# Altium schematic sheet RGB.SchDoc
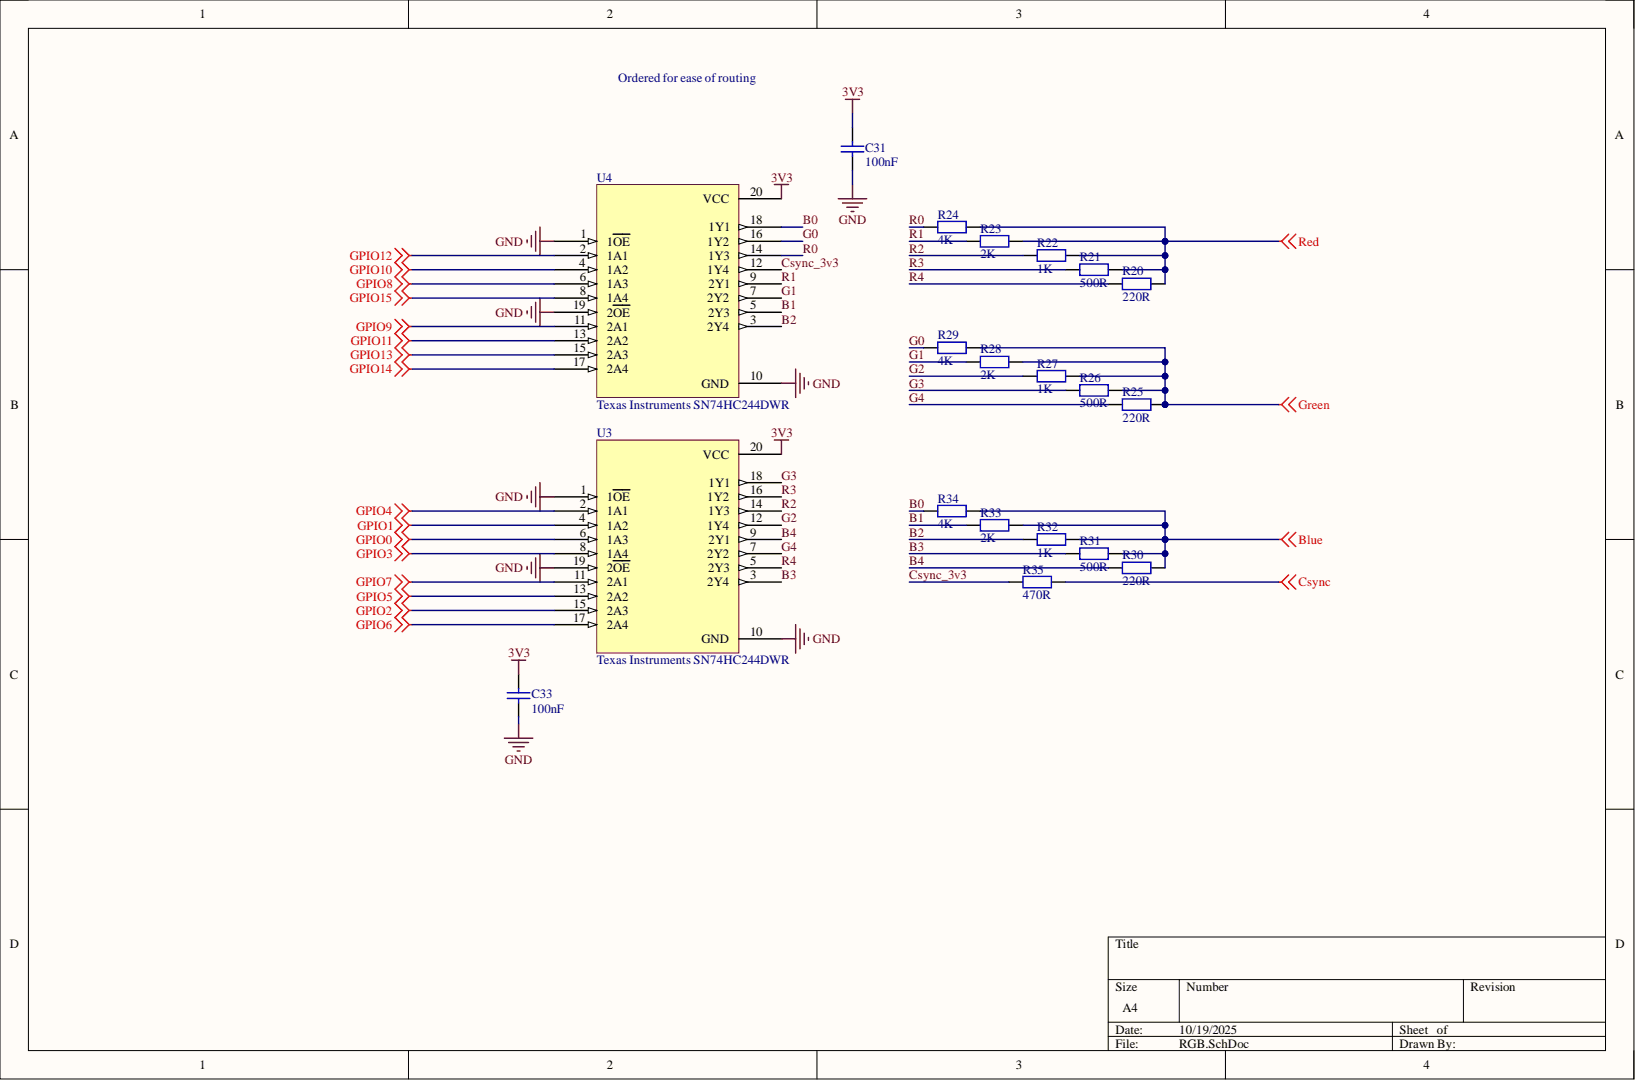
[diagram p0: RGB.SchDoc]

## Parts
C31  100nF  [PCB-god88euyegdvhk3bnqo6-1]
C33  100nF  [PCB-god88euyegdvhk3bnqo6-1]
R20  220R  [PCB-7wrvqjq7seaxrusqyahf-1]
R21  500R  [PCB-7wrvqjq7seaxrusqyahf-1]
R22  1K  [PCB-7wrvqjq7seaxrusqyahf-1]
R23  2K  [PCB-7wrvqjq7seaxrusqyahf-1]
R24  4K  [PCB-7wrvqjq7seaxrusqyahf-1]
R25  220R  [PCB-7wrvqjq7seaxrusqyahf-1]
R26  500R  [PCB-7wrvqjq7seaxrusqyahf-1]
R27  1K  [PCB-7wrvqjq7seaxrusqyahf-1]
R28  2K  [PCB-7wrvqjq7seaxrusqyahf-1]
R29  4K  [PCB-7wrvqjq7seaxrusqyahf-1]
R30  220R  [PCB-7wrvqjq7seaxrusqyahf-1]
R31  500R  [PCB-7wrvqjq7seaxrusqyahf-1]
R32  1K  [PCB-7wrvqjq7seaxrusqyahf-1]
R33  2K  [PCB-7wrvqjq7seaxrusqyahf-1]
R34  4K  [PCB-7wrvqjq7seaxrusqyahf-1]
R35  470R  [PCB-7wrvqjq7seaxrusqyahf-1]
U3  Texas Instruments SN74HC244DWR  Manufacturer Part Number: SN74HC244DWR  [TI-DW20_M]
U4  Texas Instruments SN74HC244DWR  Manufacturer Part Number: SN74HC244DWR  [TI-DW20_M]

## Nets
3V3: C31.2 C33.2 U3.20(VCC) U4.20(VCC)
Blue: R30.1 R31.1 R32.1 R33.1 R34.1
Csync: R35.1
GND: C31.1 C33.1 U3.1(1OE) U3.10(GND) U3.19(2OE) U4.1(1OE) U4.10(GND) U4.19(2OE)
GPIO0: U3.6(1A3)
GPIO1: U3.4(1A2)
GPIO2: U3.15(2A3)
GPIO3: U3.8(1A4)
GPIO4: U3.2(1A1)
GPIO5: U3.13(2A2)
GPIO6: U3.17(2A4)
GPIO7: U3.11(2A1)
GPIO8: U4.6(1A3)
GPIO9: U4.11(2A1)
GPIO10: U4.4(1A2)
GPIO11: U4.13(2A2)
GPIO12: U4.2(1A1)
GPIO13: U4.15(2A3)
GPIO14: U4.17(2A4)
GPIO15: U4.8(1A4)
Green: R25.1 R26.1 R27.1 R28.1 R29.1
Red: R20.1 R21.1 R22.1 R23.1 R24.1
B0: R34.2 U4.18(1Y1)
B1: R33.2 U4.5(2Y3)
B2: R32.2 U4.3(2Y4)
B3: R31.2 U3.3(2Y4)
B4: R30.2 U3.9(2Y1)
Csync_3v3: R35.2 U4.12(1Y4)
G0: R29.2 U4.16(1Y2)
G1: R28.2 U4.7(2Y2)
G2: R27.2 U3.12(1Y4)
G3: R26.2 U3.18(1Y1)
G4: R25.2 U3.7(2Y2)
R0: R24.2 U4.14(1Y3)
R1: R23.2 U4.9(2Y1)
R2: R22.2 U3.14(1Y3)
R3: R21.2 U3.16(1Y2)
R4: R20.2 U3.5(2Y3)

## Library data of the parts
C31 C33 = CMP-ee2a246dec7f31ed-7: Capacitor; Ceramic; Cap 0.1 uF; Tol 10%; Vol-Rtg 25 VDC; SMT; 0805; X7R; Tape & Reel; Component Kind: Standard; Library Name: My Personal Space
R20-R35 = CMP-3bd8f394a633e41f-2: Res Thin Film 2.0 x 1.2 mm 2K Ohm 0.1% 0.1W(1/10W) 10ppm/ C Molded SMD Paper T/R; Manufacturer Part Number: RN73C2A2K0BTD; Case/Package (SI): 2012; ELV Compliant: Compliant; Power Rating: 100 mW; Resistance: 2.00 kΩ; Voltage Rating (DC): 100 V; Case/Package: 0805; Component Kind: Standard; Library Name: My Personal Space; Lifecycle Status: Active; Mounting Style: Surface Mount; Number of Pins: 2; Octopart URL: http://octopart.com/rn73c2a2k0btdf-te+connectivity-21411981; OctopartID: 3bd8f394a633e41f; Packaging: Tape & Reel (TR); REACH SVHC Compliance: No SVHC; Resistance Tolerance: ±0.1%; RoHS: Compliant
U3 U4 = CMP-0defc4e5fedfd228-1: Buffer/Line Driver 8-CH Non-Inverting 3-ST CMOS Automotive 20-Pin SOIC T/R; Lead-Free Status: Lead Free; Number of Bits: 8; Output Current Drive: -1.00 mA; Propagation Delay Max (tpd): 29.0 ns; Quiescent Current: 8.00 µA (max); Supply Voltage (DC): 2.00 V to 6.00 V; Voltage Nodes: 2.00 V, 6.00 V, 4.50 V; Case/Package: SOIC; Component Kind: Standard; Library Name: My Personal Space; Lifecycle Status: Active; Mounting Style: Surface Mount; Number of Circuits: 8; Number of Outputs: 8; Number of Pins: 20; Octopart Url: https://octopart.com/sn74hc244dwr-texas+instruments-54870; OctopartID: 0defc4e5fedfd228; Operating Temperature: -40.0 °C to 85.0 °C; Packaging: Cut Tape (CT); REACH SVHC Compliance: No SVHC; RoHS: Compliant
